# Altium schematic sheet Sheet1.SchDoc(Sheet1)
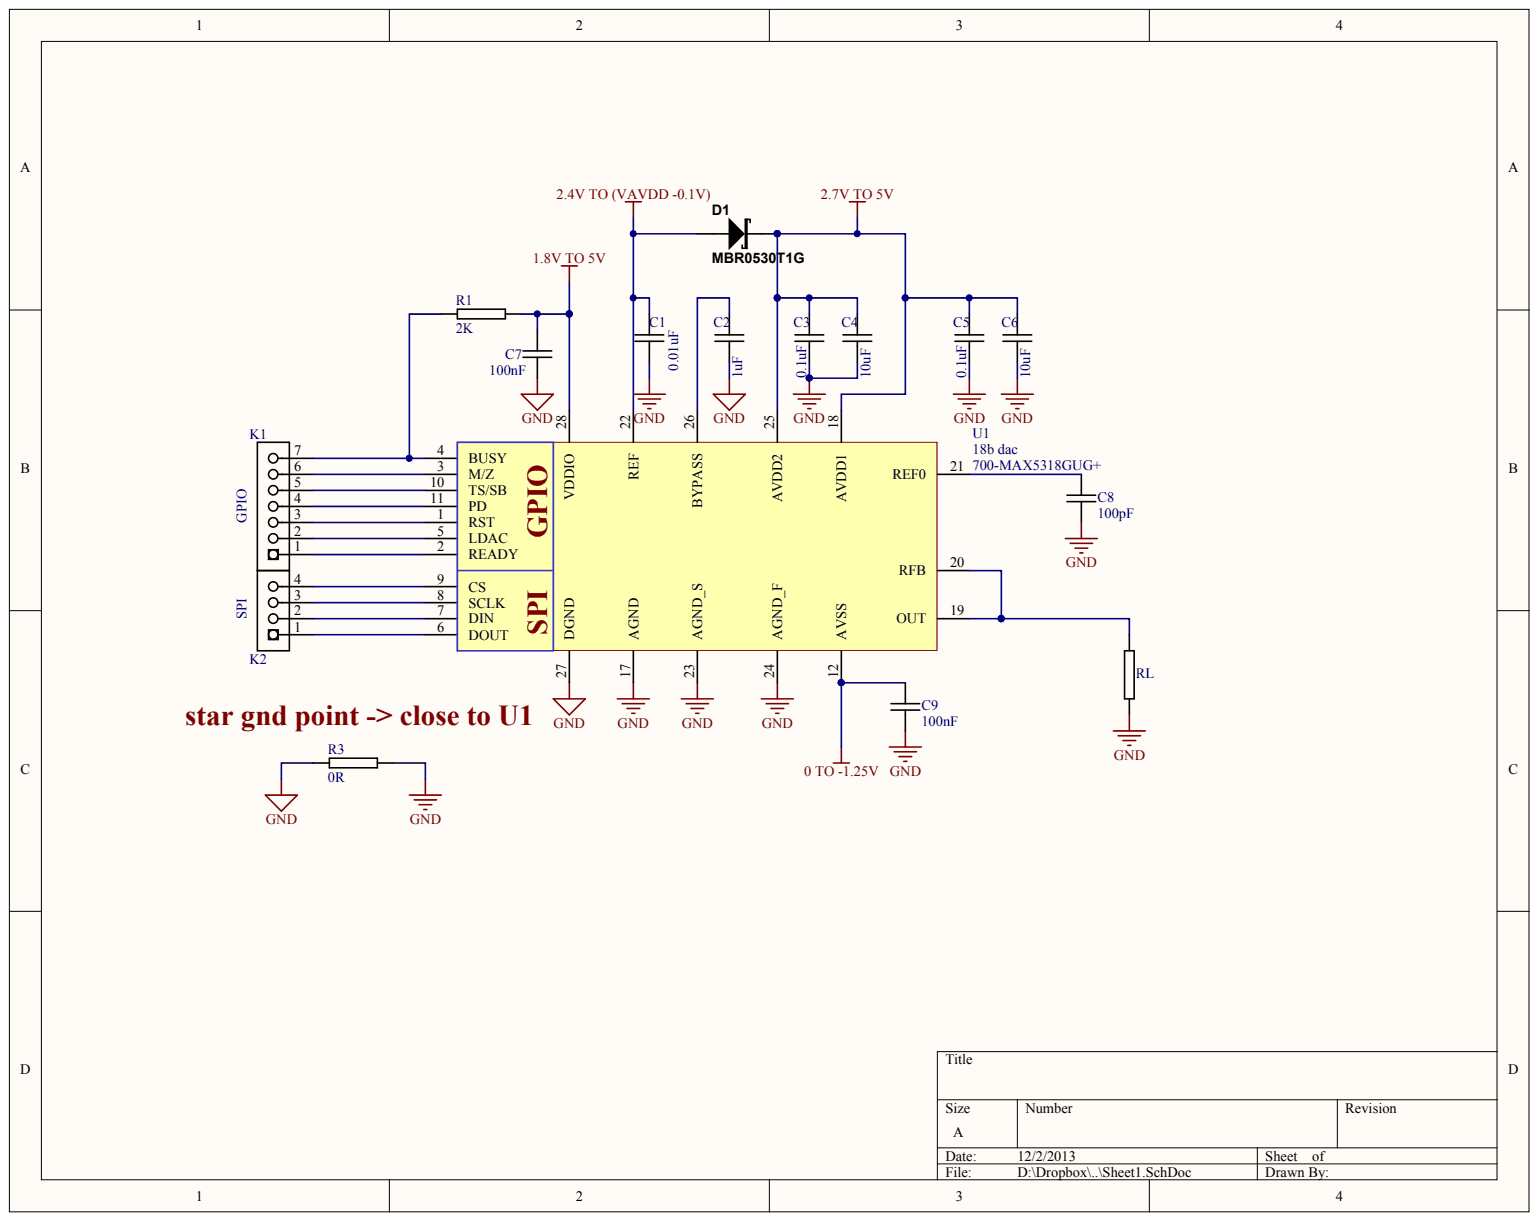
[diagram p0: Sheet1.SchDoc]

## Parts
C1  0.01uF
C2  1uF
C3  0.1uF
C4  10uF
C5  0.1uF
C6  10uF
C7  100nF
C8  100pF
C9  100nF
D1  MBR0530T1G
K1  GPIO
K2  SPI
R1  2K
R2  RL
R3  0R
U1  18b dac  Interface Type: SPI  Supplier Part Number 1: 700-MAX5318GUG+

## Nets
0 TO -1.25V: C9.2 U1.12(AVSS)
1.8V TO 5V: C7.1 R1.2 U1.28(VDDIO)
2.4V TO (VAVDD -0.1V): C1.2 D1.A U1.22(REF)
2.7V TO 5V: C3.1 C4.1 C5.1 C6.1 D1.K U1.18(AVDD1) U1.25(AVDD2)
GND: C1.1 C2.2 C3.2 C4.2 C5.2 C6.2 C7.2 C8.1 C9.1 R2.1 R3.1 R3.2 U1.17(AGND) U1.23(AGND_S) U1.24(AGND_F) U1.27(DGND)
NetC2_1: C2.1 U1.26(BYPASS)
NetC8_2: C8.2 U1.21(REF0)
NetK1_1: K1.1 U1.2(READY)
NetK1_2: K1.2 U1.5(LDAC)
NetK1_3: K1.3 U1.1(RST)
NetK1_4: K1.4 U1.11(PD)
NetK1_5: K1.5 U1.10(TS/SB)
NetK1_6: K1.6 U1.3(M/Z)
NetK1_7: K1.7 R1.1 U1.4(BUSY)
NetK2_1: K2.1 U1.6(DOUT)
NetK2_2: K2.2 U1.7(DIN)
NetK2_3: K2.3 U1.8(SCLK)
NetK2_4: K2.4 U1.9(CS)
NetR2_2: R2.2 U1.19(OUT) U1.20(RFB)

## Library data of the parts
U1 = (no symbol name): Manufacturer: Maxim Integrated; Manufacturer Part Number: MAX5318GUG+; Number of Converters: 1; Output Type: Voltage Buffered; Resolution: 18 bit; Maximum Power Dissipation: 1111.1 mW; Settling Time: 3 us; Supply Voltage - Max: 5.5 V; Supply Voltage - Min: 2.7 V; Voltage Reference: 2.5 V; Product Status: New Product; Supplier 1: Mouser; RoHS: RoHS Compliant; Category: Digital to Analog Converters - DAC; Stock 1: 57; Pricing 1: 1=38.50, 25=28.52, 50=24.37, 100=20.23, 250=17.82 (EUR); Package/Case: TSSOP-24; Series: MAX5318; Mounting Style: SMD/SMT; Maximum Operating Temperature: + 105 C; Minimum Operating Temperature: - 40 C; Number of DAC Outputs: 1; RoHS - Mouser: Y; Minimum Order Quantity: 1; Reeling: False; ComponentLink1URL: http://www.mouser.com/ds/2/256/MAX5318-246009.pdf; ComponentLink1Description: http://www.mouser.com/ds/2/256/MAX5318-246009.pdf
C1-C9 D1 K1 K2 R1-R3 = (no symbol name)
  C1-C9: Supplier 1: Digi-Key; Supplier Part Number 1: 490-1673-1-ND
  K1 K2: Supplier 1: Digi-Key; Supplier Part Number 1: S1011E-36-ND
